# Altium schematic sheet STM32F7-MINI.SchDoc
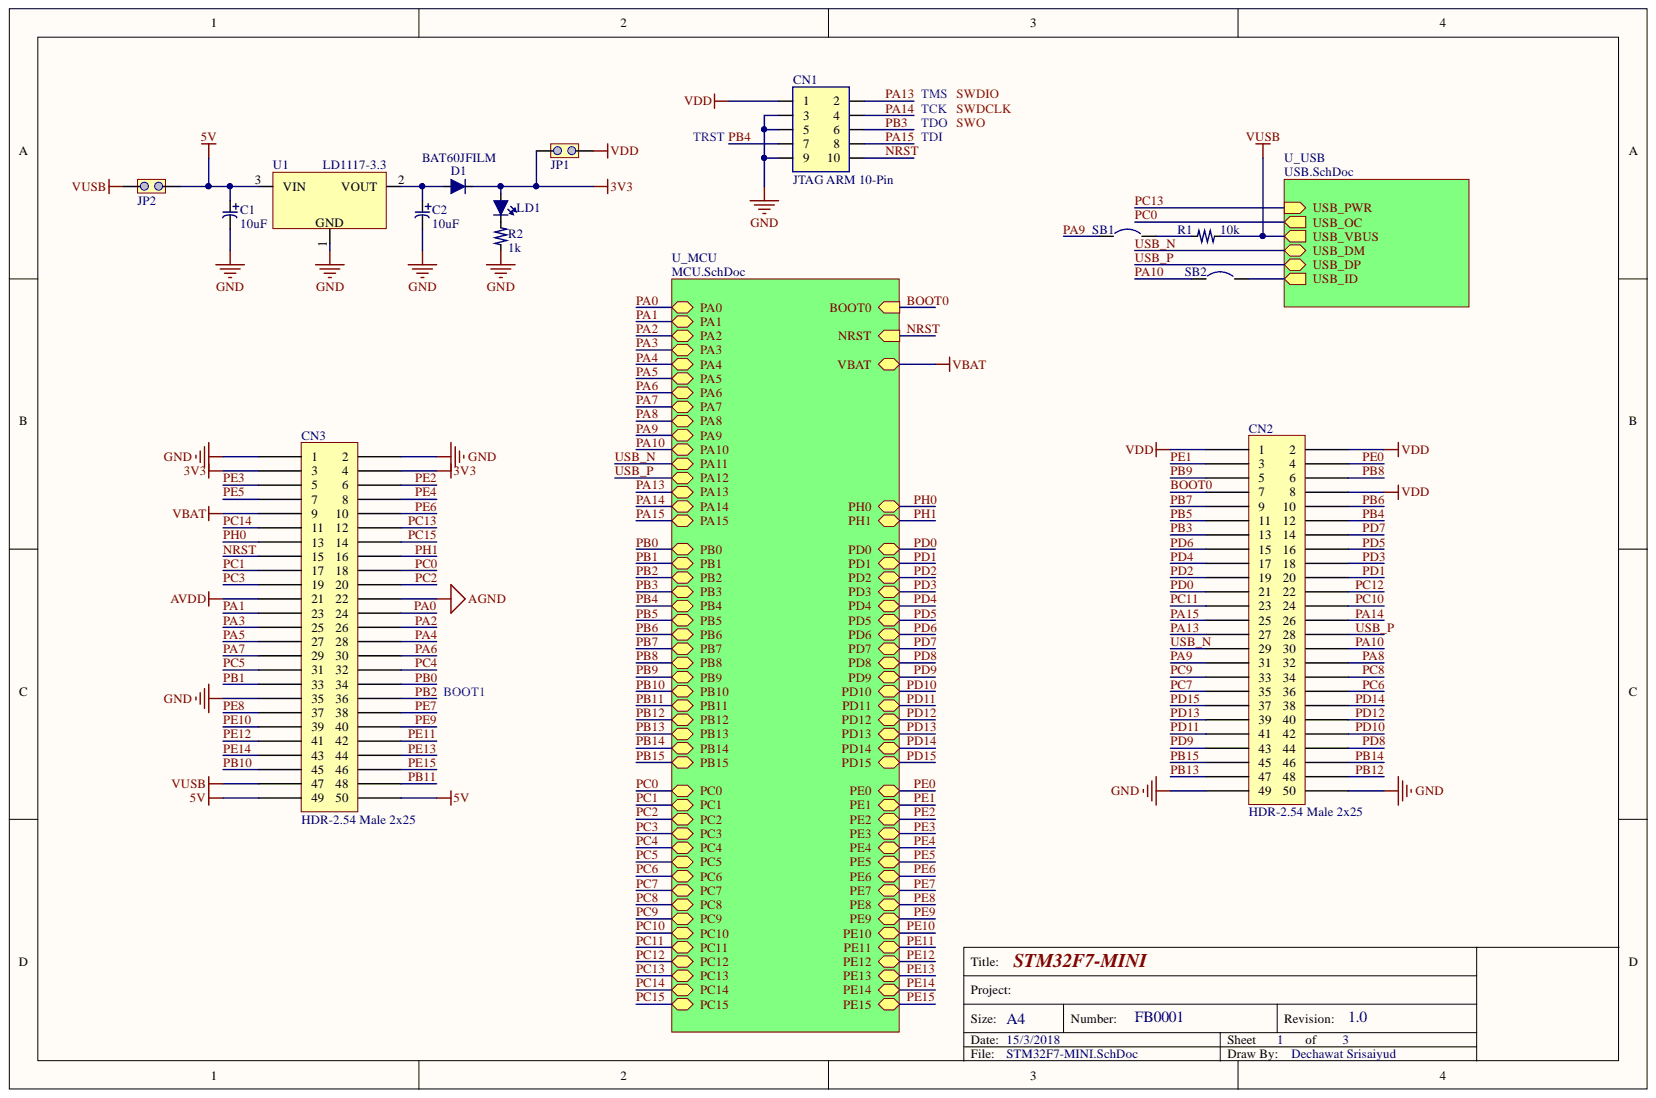
[diagram p0: STM32F7-MINI.SchDoc]

## Parts
C1  value 10uF  [Chip-1206CT]  (hidden comment: Cap 1206)
C2  value 10uF  [1210C]  (hidden comment: Cap 1210)
CN1  JTAG ARM 10-Pin  [HDR-BOX-2.54-MALE-2x5-TS]
CN2  HDR-2.54 Male 2x25  [HDR-254_MALE_2x25_BOTTOM]
CN3  HDR-2.54 Male 2x25  [HDR-254_MALE_2x25_BOTTOM]
D1  BAT60JFILM  [SOD323]
JP1  Jumper  [HDR-2.54-Male-1x2-TS]  (value not drawn)
JP2  Jumper  [HDR-2.54-Male-1x2-TS]  (value not drawn)
LD1  LED  [CHIP-0603LED]  (value not drawn)
R1  value 10k  [Chip-0603R]  (hidden comment: Res 0603)
R2  value 1k  [Chip-0603R]  (hidden comment: Res 0603)
SB1  Close  [SB_0603]  (value not drawn)
SB2  Close  [SB_0603]  (value not drawn)
U1  LD1117-3.3  [SOT-223-3]

## Nets
3V3: CN3.3 CN3.4 D1.2 JP1.1 LD1.1
5V: C1.1 CN3.49 CN3.50 JP2.2 U1.3
AGND: CN3.22
AVDD: CN3.21
GND: C1.2 C2.2 CN1.3 CN1.5 CN1.9 CN2.49 CN2.50 CN3.1 CN3.2 CN3.35 R2.1 U1.1
VBAT: CN3.9
VDD: CN1.1 CN2.1 CN2.2 CN2.8 JP1.2
VUSB: CN3.47 JP2.1 R1.2
BOOT0: CN2.7
NRST: CN1.10 CN3.15
PA0: CN3.24
PA1: CN3.23
PA2: CN3.26
PA3: CN3.25
PA4: CN3.28
PA5: CN3.27
PA6: CN3.30
PA7: CN3.29
PA8: CN2.32
PA9: CN2.31 SB1.1
PA10: CN2.30 SB2.1
PA13: CN1.2 CN2.27
PA14: CN1.4 CN2.26
PA15: CN1.8 CN2.25
PB0: CN3.34
PB1: CN3.33
PB2: CN3.36
PB3: CN1.6 CN2.13
PB4: CN1.7 CN2.12
PB5: CN2.11
PB6: CN2.10
PB7: CN2.9
PB8: CN2.6
PB9: CN2.5
PB10: CN3.45
PB11: CN3.48
PB12: CN2.48
PB13: CN2.47
PB14: CN2.46
PB15: CN2.45
PC0: CN3.18
PC1: CN3.17
PC2: CN3.20
PC3: CN3.19
PC4: CN3.32
PC5: CN3.31
PC6: CN2.36
PC7: CN2.35
PC8: CN2.34
PC9: CN2.33
PC10: CN2.24
PC11: CN2.23
PC12: CN2.22
PC13: CN3.12
PC14: CN3.11
PC15: CN3.14
PD0: CN2.21
PD1: CN2.20
PD2: CN2.19
PD3: CN2.18
PD4: CN2.17
PD5: CN2.16
PD6: CN2.15
PD7: CN2.14
PD8: CN2.44
PD9: CN2.43
PD10: CN2.42
PD11: CN2.41
PD12: CN2.40
PD13: CN2.39
PD14: CN2.38
PD15: CN2.37
PE0: CN2.4
PE1: CN2.3
PE2: CN3.6
PE3: CN3.5
PE4: CN3.8
PE5: CN3.7
PE6: CN3.10
PE7: CN3.38
PE8: CN3.37
PE9: CN3.40
PE10: CN3.39
PE11: CN3.42
PE12: CN3.41
PE13: CN3.44
PE14: CN3.43
PE15: CN3.46
PH0: CN3.13
PH1: CN3.16
USB_N: CN2.29
USB_P: CN2.28
NetC2_1: C2.1 D1.1 U1.2
NetLD1_2: LD1.2 R2.2
NetR1_1: R1.1 SB1.2
NetSB2_2: SB2.2

## Library data of the parts
C1 C2 = 1206-CT: Component Kind: Standard; Signal Integrity: Capacitor
  C2: Library Name: STM32F7-MINI.SchLib
  C1: Library Name: DechLib.IntLib
CN1 = HDR-BOX-2.54-2x5-Male-TS: Box Header 2.54mm 2x5pin Through Hole Straight; Component Kind: Standard; Library Name: DechLib.IntLib
CN2 CN3 = HDR-2.54 Male 2x20: DUAL ROW STRAIGHT TYPE 2*25 PINS; Component Kind: Standard; Library Name: STM32F7-MINI.SchLib
D1 = BAT60JFILM: Schottky Diodes & Rectifiers 3.0A/10V; Manufacturer: STMicroelectronics; Component Kind: Standard; Part No.: BAT60JFILM; Signal Integrity: Diode; Library Name: DechLib.IntLib
JP1 JP2 = Jumper: Circuit Breaker; Component Kind: Standard; Published: 24-Mar-1999; Revision: July-2002: Re-released for DXP Platform.; Library Name: DechLib.IntLib; Publisher: Altium Limited
LD1 = LED-0603: Component Kind: Standard; Signal Integrity: LED; Library Name: DechLib.IntLib
R1 R2 = 0603-R: Component Kind: Standard; Signal Integrity: Resistor; Library Name: DechLib.IntLib
SB1 SB2 = Circuit Breaker: Component Kind: Standard; Description: Circuit Breaker; Published: 24-Mar-1999; Revision: July-2002: Re-released for DXP Platform.; Library Name: DechLib.IntLib; Publisher: Altium Limited
U1 = LD1117AS33TR: Positive Fixed Voltage Regulator LDO 3.3V/1A; Manufacturer: STMicroelectronics; Component Kind: Standard; Part No.: LD1117AS33TR; Library Name: DechLib.IntLib
